# Altium schematic sheet Microbit built-in.SchDoc (continued)
## Library data of the parts (continued)
SW2 SW3 = SW ADTSM62KVTR: Switch Tactile Spst-no 0.05A 12V; Manufacturer: APEM; Category: Tactile Switches; Component Kind: Standard; ComponentLink1Description: https://datasheet.ciiva.com/12437/adts6-adtsm-ktsc6-263747-12437085.pdf?src-supplier=Mouser; ComponentLink1URL: https://datasheet.ciiva.com/12437/adts6-adtsm-ktsc6-263747-12437085.pdf?src-supplier=Mouser; Manufacturer Part Number: ADTSM62KVTR; Pricing 1: 1=0.42, 10=0.41, 25=0.386, 100=0.3351, 250=0.304 (USD); RoHS: Yes; Stock 1: 1865; Supplier 1: Digikey; Supplier Part Number 1: 679-ADTSM62KVTRCT-ND; Library Name: bBoard for UNB Rev 4.SCHLIB
U6 = NRF52833-QIAA-R: Telecom Circuit, 1-Func; Manufacturer: Nordic Semiconductor; Category: RF Receivers, Transceivers; Component Kind: Standard; Manufacturer Part Number: NRF52833-QIAA-R; Pricing 1: 1=4.58, 100=3.91, 500=3.4, 1000=3.11001 (USD); Reach SVHC Compliant: Yes; RoHS: No; Stock 1: 18870; Supplier 1: Digikey; Supplier Part Number 1: 4823-NRF52833-QIAA-RDKR-ND; Library Name: bBoard for UNB Rev 3.SCHLIB
U7 = SPU0410LR5H-QB-7: Consumer Circuit; Manufacturer: Knowles; Number of Functions: 1; Supply Voltage-Max (Vsup): 3.6V; Supply Voltage-Min (Vsup): 1.5V; Time @ Peak Reflow Temperature-Max (s): 5s; Category: Microphones; Component Kind: Standard; ComponentLink1Description: https://datasheet.ciiva.com:443/pdfs/VipMasterIC/IC/KNOW/KNOW-S-A0002642774/KNOW-S-A0002642774-1.pdf?src-supplier=IHS+Markit; ComponentLink1URL: https://datasheet.ciiva.com:443/pdfs/VipMasterIC/IC/KNOW/KNOW-S-A0002642774/KNOW-S-A0002642774-1.pdf?src-supplier=IHS+Markit; ComponentLink2Description: https://media.digikey.com/pdf/Data%20Sheets/Knowles%20Acoustics%20PDFs/SiSonic_Design_Guide.pdf?src-supplier=Digikey; ComponentLink2URL: https://media.digikey.com/pdf/Data%20Sheets/Knowles%20Acoustics%20PDFs/SiSonic_Design_Guide.pdf?src-supplier=Digikey; Consumer IC Type: CONSUMER CIRCUIT; Height - Seated (Max): 1.2mm; Length: 3.76mm; Manufacturer Part Number: SPU0410LR5H-QB-7; Max Operating Temperature: 100°C; Min Operating Temperature: -40°C; Moisture Sensitivity Level: 1; Number of Pins: 6; Package Shape: Rectangular; Package Style: MICROELECTRONIC ASSEMBLYMeter; Peak Reflow Temperature (Cel): 260°C; Pricing 1: 1=0.77, 10=0.657, 25=0.614, 50=0.5822, 100=0.5514, 250=0.51764, 500=0.48294, 1000=0.4549 (USD); RoHS: Yes; RoHS Compliant: Yes; Stock 1: 68407; Supplier 1: Digikey; Supplier Part Number 1: SPU0410LR5HDKR-ND; Surface Mount: Yes; Temperature Grade: INDUSTRIAL; Terminal Form: No Lead; Terminal Position: UNSPECIFIED; Width: 3mm; Library Name: bBoard for UNB Rev 4.SCHLIB
U8 = LSM303AGRTR: Analog Circuit, 1 Func, PBGA12; Manufacturer: STMicroelectronics; Number of Functions: 1; Package Body Material: Plastic; Supply Voltage-Max (Vsup): 3.6V; Supply Voltage-Min (Vsup): 1.71V; Supply Voltage-Nom (Vsup): 2.5V; Analog IC - Other Type: ANALOG CIRCUIT; Category: Inertial Measurement Units (IMUs); Component Kind: Standard; ComponentLink1Description: https://datasheet.ciiva.com:443/pdfs/VipMasterIC/IC/SGST/SGST-S-A0006927870/SGST-S-A0006927870-1.pdf?src-supplier=IHS+Markit; ComponentLink1URL: https://datasheet.ciiva.com:443/pdfs/VipMasterIC/IC/SGST/SGST-S-A0006927870/SGST-S-A0006927870-1.pdf?src-supplier=IHS+Markit; ComponentLink2Description: http://www.farnell.com/datasheets/2151221.pdf?src-supplier=Farnell; ComponentLink2URL: http://www.farnell.com/datasheets/2151221.pdf?src-supplier=Farnell; ComponentLink3Description: https://www.mouser.com/ds/2/389/lsm303agr-954987.pdf?src-supplier=Mouser; ComponentLink3URL: https://www.mouser.com/ds/2/389/lsm303agr-954987.pdf?src-supplier=Mouser; ComponentLink4Description: https://datasheet.octopart.com/LSM303AGRTR-STMicroelectronics-datasheet-67357094.pdf?src-supplier=Element14; ComponentLink4URL: https://datasheet.octopart.com/LSM303AGRTR-STMicroelectronics-datasheet-67357094.pdf?src-supplier=Element14; ComponentLink5Description: http://www.farnell.com/datasheets/2151221.pdf?src-supplier=Newark; ComponentLink5URL: http://www.farnell.com/datasheets/2151221.pdf?src-supplier=Newark; ComponentLink6Description: http://www.st.com/content/ccc/resource/technical/document/datasheet/74/c4/19/54/62/c5/46/13/DM00177685.pdf/files/DM00177685.pdf/jcr:content/translations/en.DM00177685.pdf?src-supplier=Digikey; ComponentLink6URL: http://www.st.com/content/ccc/resource/technical/document/datasheet/74/c4/19/54/62/c5/46/13/DM00177685.pdf/files/DM00177685.pdf/jcr:content/translations/en.DM00177685.pdf?src-supplier=Digikey; Height - Seated (Max): 1mm; Length: 2mm; Manufacturer Part Number: LSM303AGRTR; Max Operating Temperature: 85°C; Min Operating Temperature: -40°C; Number of Pins: 12; Package Code: VFLGA; Package Shape: Square; Package Style: GRID ARRAY, VERY THIN PROFILE, FINE PITCHMeter; Pricing 1: 1=6.97, 10=5.227, 25=4.646, 50=4.4136, 100=4.2976, 500=3.60064, 1000=3.36835 (USD); Reach SVHC Compliant: Yes; RoHS: Yes; RoHS Compliant: Yes; Stock 1: 45496; Supplier 1: Digikey; Supplier Part Number 1: 497-16527-1-ND; Surface Mount: Yes; Temperature Grade: INDUSTRIAL; Terminal Form: BUTT; Terminal Pitch: 0.5mm; Terminal Position: BOTTOM; Width: 2mm; Library Name: bBoard for UNB Rev 4.SCHLIB
X1 = XTAL ECS-320-16-33B-CKM-TR: Parallel - Fundamental Quartz Crystal, 32MHz Nom; Manufacturer: ECS International; Component Kind: Standard; ComponentLink1Description: https://datasheet.ciiva.com:443/pdfs/VipMasterIC/IC/ECSI/ECSI-S-A0004428075/ECSI-S-A0004428075-1.pdf?src-supplier=IHS+Markit; ComponentLink1URL: https://datasheet.ciiva.com:443/pdfs/VipMasterIC/IC/ECSI/ECSI-S-A0004428075/ECSI-S-A0004428075-1.pdf?src-supplier=IHS+Markit; ComponentLink2Description: https://ecsxtal.com/store/pdf/ECS-33B.pdf?src-supplier=Digikey; ComponentLink2URL: https://ecsxtal.com/store/pdf/ECS-33B.pdf?src-supplier=Digikey; Crystal/Resonator Type: PARALLEL - FUNDAMENTAL; Manufacturer Part Number: ECS-320-16-33B-CKM-TR; Pricing 1: 1=0.61, 10=0.505, 50=0.4544, 100=0.404, 500=0.3838 (USD); Reach SVHC Compliant: Yes; RoHS: Yes; RoHS Compliant: Yes; Stock 1: 1933; Supplier 1: Digikey; Supplier Part Number 1: XC2531CT-ND; Library Name: bBoard for UNB Rev 4.SCHLIB
Touch1 = UNB Logo: Component Kind: Standard; Library Name: bBoard for UNB Rev 4.SCHLIB
